# Altium schematic sheet PowerSupply.SchDoc(PowerSupply)
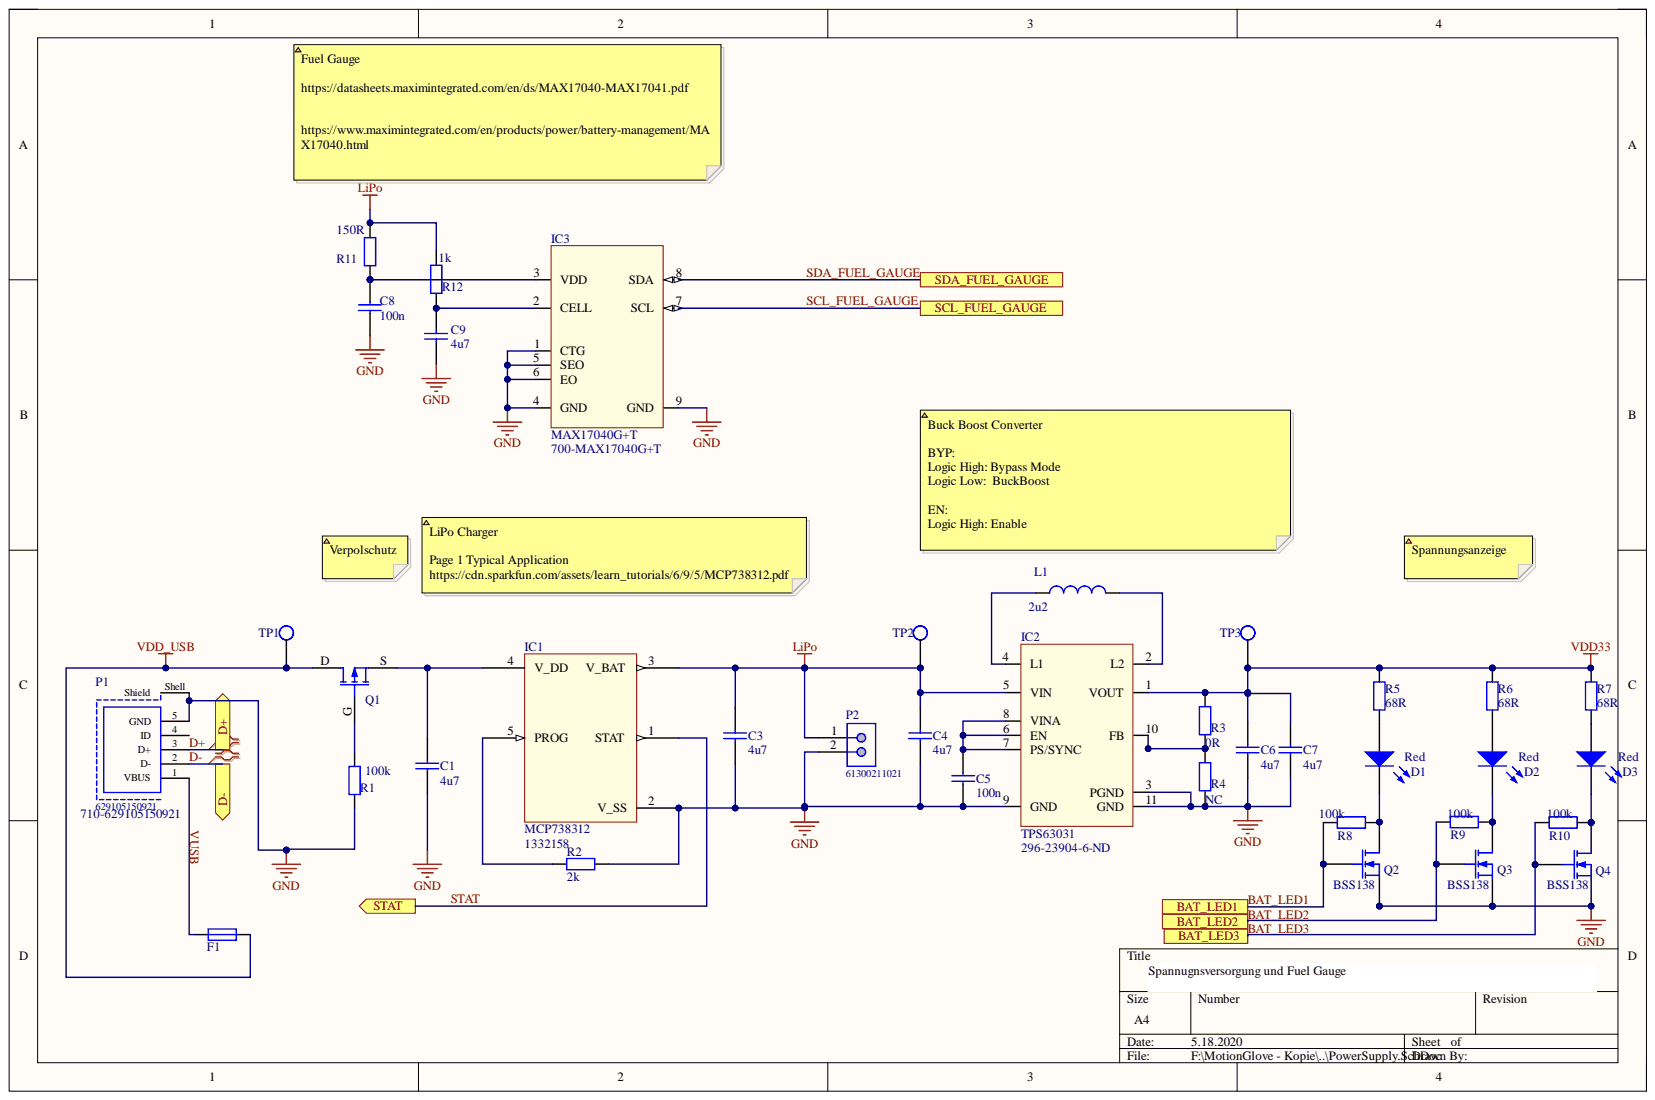
[diagram p0: PowerSupply.SchDoc]

## Parts
C1  4u7  [CAPC1005X55]
C3  4u7  [CAPC1005X55]
C4  4u7  [CAPC1005X55]
C5  100n  [CAPC1005X55]
C6  4u7  [CAPC1005X55]
C7  4u7  [CAPC1005X55]
C8  100n  [CAPC1005X55]
C9  4u7  [CAPC1005X55]
D1  Red  [LEDC2012X90]
D2  Red  [LEDC2012X90]
D3  Red  [LEDC2012X90]
F1  (no value)  [RESC3216X65]
IC1  MCP738312  [MCP738312]
IC2  TPS63031  Supplier Part Number 1: 296-23904-6-ND  [TPS63031]
IC3  MAX17040G+T  Supplier Part Number 1: 700-MAX17040G+T  [MAX17040G+T]
L1  2u2  [INDM3030X150]
P1  629105150921  Supplier Part Number 1: 710-629105150921  [629105150921]
P2  61300211021  [61300211021]
Q1  IRLML9301TRPBF  [SOT95P240X130_SGD-3]  (value not drawn)
Q2  BSS138  [SOT95P240X130_SGD-3]
Q3  BSS138  [SOT95P240X130_SGD-3]
Q4  BSS138  [SOT95P240X130_SGD-3]
R1  100k  [RESC1005X40]
R2  2k  [RESC1005X40]
R3  0R  [RESC1005X40]
R4  NC  value 0R  [RESC1005X40]
R5  68R  [RESC1005X40]
R6  68R  [RESC1005X40]
R7  68R  [RESC1005X40]
R8  100k  [RESC1005X40]
R9  100k  [RESC1005X40]
R10  100k  [RESC1005X40]
R11  150R  [RESC1005X40]
R12  1k  [RESC1005X40]
TP1  Testpoint  [TP200A]  (value not drawn)  (no BOM)
TP2  Testpoint  [TP200A]  (value not drawn)  (no BOM)
TP3  Testpoint  [TP200A]  (value not drawn)  (no BOM)

## Ports
BAT_LED1, BAT_LED2, BAT_LED3, D+, D-, SCL_FUEL_GAUGE, SDA_FUEL_GAUGE, STAT

## Nets
GND: C1.1 C3.1 C4.1 C5.2 C6.1 C7.1 C8.1 C9.1 IC1.2(V_SS) IC2.3(PGND) IC2.9(GND) IC2.11(GND) IC3.1(CTG) IC3.4(GND) IC3.5(SEO) IC3.6(EO) IC3.9(GND) P1.5(GND) P1.Shell(Shield) P2.2 Q2.S Q3.S Q4.S R1.1 R2.1 R4.1
LiPo: C3.2 C4.2 IC1.3(V_BAT) IC2.5(VIN) P2.1 R11.2 R12.2 TP2.1
VDD33: C6.2 C7.2 IC2.1(VOUT) R3.2 R5.2 R6.2 R7.2 TP3.1
VDD_USB: F1.2 Q1.D TP1.1
BAT_LED1: Q2.G R8.1 ; port BAT_LED1
BAT_LED2: Q3.G R9.1 ; port BAT_LED2
BAT_LED3: Q4.G R10.1 ; port BAT_LED3
D+: P1.3(D+) ; port D+
D-: P1.2(D-) ; port D-
SCL_FUEL_GAUGE: IC3.7(SCL) ; port SCL_FUEL_GAUGE
SDA_FUEL_GAUGE: IC3.8(SDA) ; port SDA_FUEL_GAUGE
STAT: IC1.1(STAT) ; port STAT
NetC1_2: C1.2 IC1.4(V_DD) Q1.S
NetC5_1: C5.1 IC2.6(EN) IC2.7(PS/SYNC) IC2.8(VINA)
NetC8_2: C8.2 IC3.3(VDD) R11.1
NetC9_2: C9.2 IC3.2(CELL) R12.1
NetD1_1: D1.1 Q2.D R8.2
NetD1_2: D1.2 R5.1
NetD2_1: D2.1 Q3.D R9.2
NetD2_2: D2.2 R6.1
NetD3_1: D3.1 Q4.D R10.2
NetD3_2: D3.2 R7.1
NetF1_1: F1.1 P1.1(VBUS)
NetIC1_5: IC1.5(PROG) R2.2
NetIC2_2: IC2.2(L2) L1.2
NetIC2_4: IC2.4(L1) L1.1
NetIC2_10: IC2.10(FB) R3.1 R4.2
NetQ1_G: Q1.G R1.2
VUSB:

Not connected: P1 4(ID)

## Library data of the parts
C1 C9 = 1257: Ceramic Chip Capacitor; Manufacturer: TDK; Manufacturer ID: C1005X5R1A475K050BC; Characteristic: X5R; Database Table Name: Capacitors; Tolerance: ±10%; Type: Standard Ceramic; UBiKS Number: UBiKS-C00144Y; Voltage: 10V; Component Kind: Standard; ComponentLink1Description: Website; ComponentLink1URL: http://product.tdk.com/capacitor/mlcc/detailed_information.php?lang=en&part_no=C1005X5R1A475K050BC; ComponentLink2Description: Datasheet; ComponentLink2URL: http://product.tdk.com/en/catalog/datasheets/mlcc_commercial_general_en.pdf; Dielectric: Ceramic; Digikey: 445-13820-1-ND; Distributor 3: Mouser; Distributor Number 3: 810-C1005X5R1A475KBC; Footprint / Size: C0402; ID: 1257; Value: 4u7; Library Name: SCT_MySQL_5.1.SVNDbLib
C3 C4 C6 C7 = 1253: Ceramic Chip Capacitor; Manufacturer: Murata; Manufacturer ID: GRM155R60J475ME47D; Characteristic: X5R; Database Table Name: Capacitors; Tolerance: ±20%; Type: Standard Ceramic; UBiKS Number: UBiKS-C00140A; Voltage: 6.3V; Component Kind: Standard; ComponentLink1Description: Website; ComponentLink1URL: http://www.murata.com/; ComponentLink2Description: Datasheet; ComponentLink2URL: http://www.farnell.com/datasheets/1769893.pdf; Dielectric: Ceramic; Farnell: 2426952; Footprint / Size: C0402; ID: 1253; Value: 4u7; Library Name: SCT_MySQL_5.1.SVNDbLib
C5 = 1066: Manufacturer: Kemet; Manufacturer ID: C0402C104K4RAC; Characteristic: X7R; Database Table Name: Capacitors; Dielectric: Ceramic; Tolerance: ±15%; Type: Standard Ceramic; UBiKS Number: UBiKS-C00001I; Voltage: 16V; Component Kind: Standard; ComponentLink1Description: Website; ComponentLink1URL: http://kemet.com/; ComponentLink2Description: Datasheet; ComponentLink2URL: http://www.kemet.com/kemet/web/homepage/kechome.nsf/vapubfiles/F3102_X7R.pdf/$file/F3102_X7R.pdf; Digikey: 399-3521-1-ND; Distributor 3: Mouser; Distributor Number 3: 80-C0402C104K4RAUTO; Farnell: 1288252; Footprint / Size: C0402; ID: 1066; Quantity: 0; Unit Price: 0; Value: 100n; Library Name: SCT_MySQL_5.1.SVNDbLib
C8 = 1066: Ceramic Chip Capacitor; Manufacturer: Kemet; Manufacturer ID: C0402C104K4RAC; Characteristic: X7R; Database Table Name: Capacitors; Tolerance: ±15%; Type: Standard Ceramic; UBiKS Number: UBiKS-C00001I; Voltage: 16V; Component Kind: Standard; ComponentLink1Description: Website; ComponentLink1URL: http://kemet.com/; ComponentLink2Description: Datasheet; ComponentLink2URL: http://www.kemet.com/kemet/web/homepage/kechome.nsf/vapubfiles/F3102_X7R.pdf/$file/F3102_X7R.pdf; Dielectric: Ceramic; Digikey: 399-3521-1-ND; Distributor 3: Mouser; Distributor Number 3: 80-C0402C104K4RAUTO; Farnell: 1288252; Footprint / Size: C0402; ID: 1066; Quantity: 0; Unit Price: 0; Value: 100n; Library Name: SCT_MySQL_5.1.SVNDbLib
D1-D3 = 6010: SMD Chip LED; Manufacturer: Avago; Manufacturer ID: HSMS-C170; Database Table Name: Optoelectronics; Type: Standard LED; UBiKS Number: UBiKS-V00002H; Color: red; Component Kind: Standard; ComponentLink1Description: Website; ComponentLink1URL: http://www.avagotech.com/; ComponentLink2Description: Datasheet; ComponentLink2URL: http://www.avagotech.com/docs/AV02-0376EN; Farnell: 5790839; Footprint / Size: LED0805; ID: 6010; Library Name: SCT_MySQL_5.1.SVNDbLib
F1 = 8021: 0.5A PTC Fuse; Manufacturer: POLY-FUSE; Manufacturer ID: 1206L050/15YR; Database Table Name: Misc; UBiKS Number: UBiKS-M00020W; Component Kind: Standard; ComponentLink1Description: Website; ComponentLink1URL: http://www.littelfuse.de/; ComponentLink2Description: Datasheet; ComponentLink2URL: http://www.littelfuse.com/data/en/Data_Sheets/Littelfuse_PTC_1206L.pdf; Digikey: F3733TR-ND; Footprint / Size: 3.2mm x 1.6 mm x 0.75mm; ID: 8021; Type: Fuse; Library Name: SCT_MySQL_5.1.SVNDbLib
L1 = 3006: SMD Inductor; Manufacturer: Taiyo Yuden; Manufacturer ID: NR3015T2R2M; Database Table Name: Inductors; max DC Current: 1.48A; Resistance: 72mR; Tolerance: ± 20%; UBiKS Number: UBiKS-L00005P; Component Kind: Standard; ComponentLink1Description: Website; ComponentLink1URL: http://www.yuden.co.jp/; ComponentLink2Description: Datasheet; ComponentLink2URL: http://www.yuden.co.jp/ut/product/pdf/enr4_e.pdf; Digikey: 587-1648-6-ND; Footprint / Size: IND3x3x1,5mm; ID: 3006; Type: Inductor; Value: 2u2; Library Name: SCT_MySQL_5.1.SVNDbLib
P1 = 629105150921: Micro USB 2.0 Type AB Receptacle WR-COM, Horizontal, SMT; Contact Resistance: 30 mOhm; Rated Current: 1.8 A; Working Voltage: 30 VAC; Component Kind: Standard; ComponentLink1Description: Manufacturer; ComponentLink1URL: http://www.we-online.de; ComponentLink2Description: Datasheet; ComponentLink2URL: https://katalog.we-online.de/em/datasheet/629105150921.pdf; Connector Type: Micro USB 2.0 Type AB; Gender: Receptacle; Library Name: USB Connectors WR-USB.SchLib; Mounting Technology: SMT; Operating Temperature: -40 to 85 degC; Orientation: Horizontal; Packaging: Tape and Reel; Part Number: 629105150921; Published Date: September 2018; Supplier 1: Mouser; Publisher: Wurth Elektronik
P2 = 61300211021: THT Angled Pin Header WR-PHD, Pitch 2.54 mm, Single Row, 2 pins; Contact Resistance: 20 mOhm; Gender: Male; Pin Number: 2; Pitch: 2.54 mm; Rated Current: 3 A; Withstanding Voltage: 500 VAC; Working Voltage: 250 VAC; Component Kind: Standard; ComponentLink1Description: Manufacturer; ComponentLink1URL: http://www.we-online.de; ComponentLink2Description: Datasheet; ComponentLink2URL: https://katalog.we-online.de/em/datasheet/6130xx11021.pdf; Library Name: ALTIUM-PHD_2_54_THT_ANGLED_PIN_HEADER_6130XX11021-rev18b (1).IntLib; Mounting Technology: THT; Operating Temperature: -40 to 105 degC; Orientation: Angled; Packaging: Bag; Part Number: 61300211021; Published Date: August 2018; Row Number: Single; Publisher: Wurth Elektronik
Q1 = 4524: P-channel enhancement mode MOS transistor; Manufacturer: International Rectifier; Database Table Name: Transistors; Type: MOSFET P enh.; UBiKS Number: UBiKS-Q00018R; Component Kind: Standard; ComponentLink1Description: Website; ComponentLink1URL: http://www.irf.com; ComponentLink2Description: Datasheet; ComponentLink2URL: http://www.irf.com/product-info/datasheets/data/irlml9301pbf.pdf; Digikey: IRLML9301TRPBFCT-ND; Farnell: 1831089RL; Footprint / Size: SOT-23; ID: 4524; Manufacturer ID: IRLML9301TRPBF; Library Name: SCT_MySQL_5.1.SVNDbLib
Q2-Q4 = 4512: N-channel enhancement mode MOS transistor; Manufacturer: Infineon; Database Table Name: Transistors; Type: MOSFET N enh.; UBiKS Number: UBiKS-Q00007G; Component Kind: Standard; ComponentLink1Description: Website; ComponentLink1URL: http://www.infineon.com; ComponentLink2Description: Datasheet; ComponentLink2URL: http://www.farnell.com/datasheets/46045.pdf; Farnell: 1156434; Footprint / Size: SOT-23; ID: 4512; Manufacturer ID: BSS138; Library Name: SCT_MySQL_5.1.SVNDbLib
R1 R8-R10 = 2121: Resistor; Manufacturer: Multicomp; Manufacturer ID: MC 0.0625W 0402 1% 100K; Database Table Name: Resistors; Element Type: Thick Film; Power rating: 0.063W; Temperatur coeff: ±100ppm/°C; Tolerance: ±1%; UBiKS Number: UBiKS-R00005V; Component Kind: Standard; ComponentLink1Description: Website; ComponentLink2Description: Datasheet; ComponentLink2URL: http://www.farnell.com/datasheets/318400.pdf; Farnell: 1358096; Footprint / Size: R0402; ID: 2121; Type: Standard; Value: 100k; Library Name: SCT_MySQL_5.1.SVNDbLib
R2 = 2144: Resistor; Manufacturer: Multicomp; Manufacturer ID: MC 0.0625W 0402 1% 2K; Database Table Name: Resistors; Element Type: Thick Film; Power rating: 0.063W; Temperatur coeff: ±100ppm/°C; Tolerance: ±1%; UBiKS Number: UBiKS-R00028X; Component Kind: Standard; ComponentLink1Description: Website; ComponentLink2Description: Datasheet; ComponentLink2URL: http://www.farnell.com/datasheets/318400.pdf; Farnell: 1358050; Footprint / Size: R0402; ID: 2144; Type: Standard; Value: 2k; Library Name: SCT_MySQL_5.1.SVNDbLib
R3 R4 = 2117: Resistor; Manufacturer: Multicomp; Manufacturer ID: MC 0.0625W 0402 1% 0R; Database Table Name: Resistors; Element Type: Thick Film; Power rating: 0.063W; Temperatur coeff: ±200ppm/°C; Tolerance: ±1%; UBiKS Number: UBiKS-R00001X; Component Kind: Standard; ComponentLink1Description: Website; ComponentLink2Description: Datasheet; ComponentLink2URL: http://www.farnell.com/datasheets/318400.pdf; Farnell: 1357983; Footprint / Size: R0402; ID: 2117; Type: Standard; Library Name: SCT_MySQL_5.1.SVNDbLib
  R3: Value: 0R
R5-R7 = 2292: Resistor; Manufacturer: Multicomp; Manufacturer ID: MC 0.0625W 0402 1% 68R; Database Table Name: Resistors; Element Type: Thick Film; Power rating: 0.063W; Temperatur coeff: ±200ppm/°C; Tolerance: ±1%; UBiKS Number: UBiKS-R00160Z; Component Kind: Standard; ComponentLink1Description: Website; ComponentLink2Description: Datasheet; ComponentLink2URL: http://www.farnell.com/datasheets/318400.pdf; Farnell: 1358011; Footprint / Size: R0402; ID: 2292; Quantity: 0; Type: Standard; Unit Price: 0; Value: 68R; Library Name: SCT_MySQL_5.1.SVNDbLib
R11 = 2219: Resistor; Manufacturer: Multicomp; Manufacturer ID: MC 0.0625W 0402 1% 150R; Database Table Name: Resistors; Element Type: Thick Film; Power rating: 0.063W; Temperatur coeff: ±100ppm/°C; Tolerance: ±1%; UBiKS Number: UBiKS-R00096U; Component Kind: Standard; ComponentLink1Description: Website; ComponentLink2Description: Datasheet; ComponentLink2URL: http://www.farnell.com/datasheets/318400.pdf; Farnell: 1358020; Footprint / Size: R0402; ID: 2219; Type: Standard; Value: 150R; Library Name: SCT_MySQL_5.1.SVNDbLib
R12 = 2154: Resistor; Manufacturer: Multicomp; Manufacturer ID: MC 0.0625W 0402 1% 1K; Database Table Name: Resistors; Element Type: Thick Film; Power rating: 0.063W; Temperatur coeff: ±100ppm/°C; Tolerance: ±1%; UBiKS Number: UBiKS-R00038C; Component Kind: Standard; ComponentLink1Description: Website; ComponentLink2Description: Datasheet; ComponentLink2URL: http://www.farnell.com/datasheets/318400.pdf; Farnell: 1358043; Footprint / Size: R0402; ID: 2154; Type: Standard; Value: 1k; Library Name: SCT_MySQL_5.1.SVNDbLib
TP1-TP3 = 8002: Testpoint 2mm; Database Table Name: Misc; UBiKS Number: UBiKS-M00002Y; ID: 8002; Type: Testpoint; Library Name: SCT_MySQL_5.1.SVNDbLib
IC1 = MCP738312: Component Kind: Standard; Library Name: Lib.SchLib; Supplier 1: Farnell; Supplier Part Number 1: 1332158
IC2 = TPS63031: Component Kind: Standard; Library Name: Lib.SchLib; Supplier 1: Digi-Key
IC3 = MAX17040G+T: Component Kind: Standard; Library Name: Lib.SchLib; Supplier 1: Mouser
